# Altium schematic sheet ZBT Radio.SchDoc("ZBT Radio")
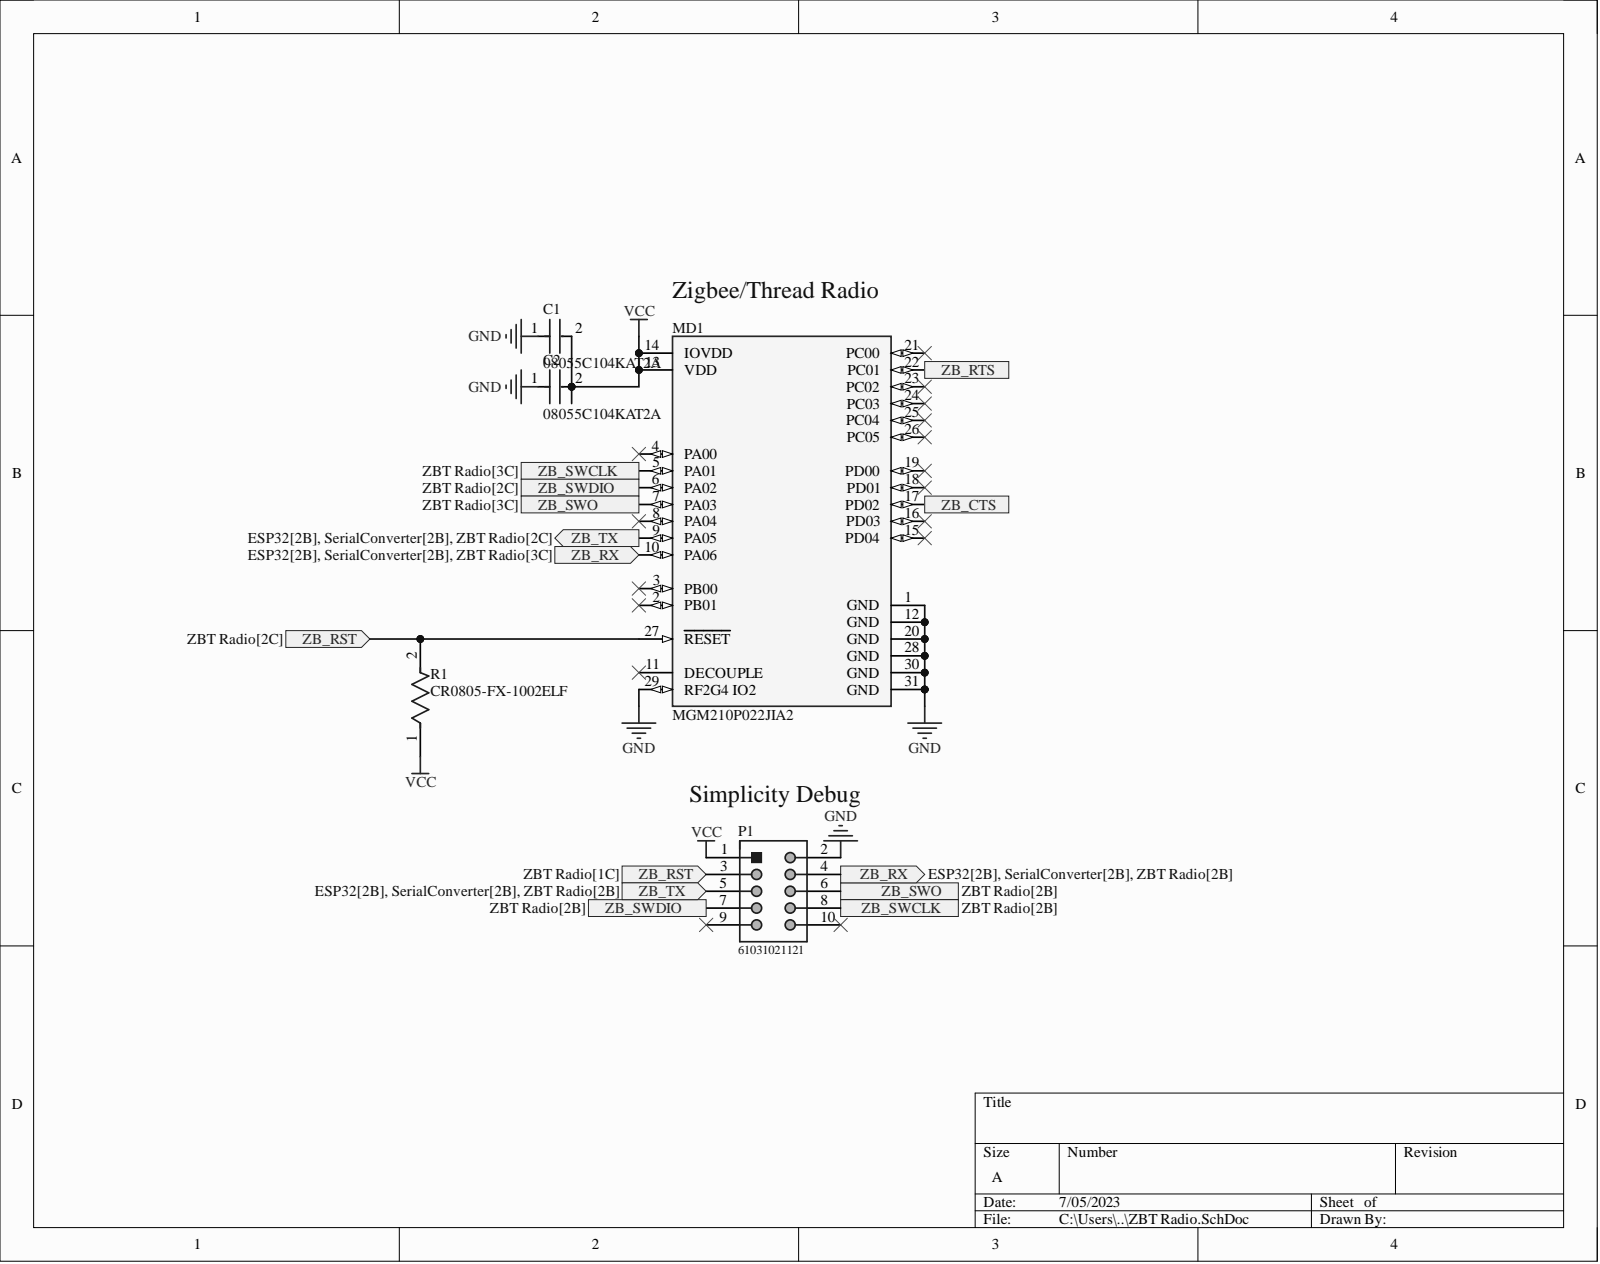
[diagram p0: ZBT Radio.SchDoc]

## Parts
C1  08055C104KAT2A  [FP-0805-L_2_01_0_2-W_1_25-IPC_C]
C2  08055C104KAT2A  [FP-0805-L_2_01_0_2-W_1_25-IPC_C]
MD1  MGM210P022JIA2  [FP-MGM210P022JIA2-MFG]
P1  61031021121  [61031021121]
R1  CR0805-FX-1002ELF  [FP-CR0805-IPC_A]

## Ports
ZB_CTS, ZB_RST, ZB_RTS, ZB_RX, ZB_SWCLK, ZB_SWDIO, ZB_SWO, ZB_TX

## Nets
GND: C1.1 C2.1 MD1.1(GND) MD1.12(GND) MD1.20(GND) MD1.28(GND) MD1.29(RF2G4_IO2) MD1.30(GND) MD1.31(GND) P1.2
VCC: C1.2 C2.2 MD1.13(VDD) MD1.14(IOVDD) P1.1 R1.1
ZB_CTS: MD1.17(PD02) ; port ZB_CTS
ZB_RST: MD1.27(RESET) P1.3 R1.2 ; port ZB_RST
ZB_RTS: MD1.22(PC01) ; port ZB_RTS
ZB_RX: MD1.10(PA06) P1.4 ; port ZB_RX
ZB_SWCLK: MD1.5(PA01) P1.8 ; port ZB_SWCLK
ZB_SWDIO: MD1.6(PA02) P1.7 ; port ZB_SWDIO
ZB_SWO: MD1.7(PA03) P1.6 ; port ZB_SWO
ZB_TX: MD1.9(PA05) P1.5 ; port ZB_TX

Not connected: MD1 2(PB01) 3(PB00) 4(PA00) 8(PA04) 11(DECOUPLE) 15(PD04) 16(PD03) 18(PD01) 19(PD00) 21(PC00) 23(PC02) 24(PC03) 25(PC04) 26(PC05); P1 9 10

## Library data of the parts
C1 C2 = CMP-2000-05016-2: General Purpose Ceramic Capacitor, 0805, 100nF, 10%, X7R, 0.15, 50V; Dissipation Factor: 2.5%; Insulation Resistance: 100GR; Capacitance: 100nF; Case Code (Imperial): 0805; Case Code (Metric): 2012; Case/Package: 0805; Component Kind: Standard; Depth: 1.25mm; Dielectric: X7R; Dielectric Material: Ceramic; Height: 0.5mm; Lead Free: Lead Free; Length: 2mm; Library Name: Altium Content Vault; Max Operating Temperature: 125°C; Min Operating Temperature: -55°C; Mount: Surface Mount; Number of Pins: 2; Package Quantity: 4000; Packaging: Cut Tape; Radiation Hardening: No; REACH SVHC: No SVHC; RoHS Compliant: Yes; Series: MLCC X7R; Termination: Standard; Thickness: 0.037inch; Tolerance: 10%; Voltage: 50V; Voltage Rating: 50V; Voltage Rating (DC): 50V; Weight: 0.001411oz; Width: 1.2mm
MD1 = CMP-08140-000019-1: RX TXRX MOD BLUETOOTH CHIP SMD; Component Kind: Standard; Library Name: Altium Content Vault
P1 = CMP-1502-01053-1: SMT Vertical Pin Header WR-PHD, Pitch 2.54 mm, Dual Row, 10 pins; Manufacturer: Wurth Elektronik; Contact Resistance: 20mOhm; Gender: Male; Pin Number: 10; Pitch: 2.54mm; Rated Current: 3A; Withstanding Voltage: 500VAC; Working Voltage: 250VAC; Component Kind: Standard; Datasheet URL: https://katalog.we-online.de/em/datasheet/6103xx21121.pdf; Library Name: Altium Content Vault; Manufacturer URL: http://www.we-online.de; Mounting Technology: SMT; Operating Temperature: -40to125degC; Orientation: Vertical; Package Reference: 61031021121; Packaging: TapeandReel; Part Number: 61031021121; Row Number: Dual; Publisher: WurthElektronik
R1 = CMP-07231-003204-1: Thick Film Chip Resistors 0805 10kΩ 0.125W 1% 100ppm/°C; Max Power Dissipation: 125mW; Resistance: 10kR; Voltage Rating: 150V; Voltage Rating (DC): 100V; Case Code (Imperial): 0805; Case Code (Metric): 2012; Case/Package: 0805; Component Kind: Standard; Composition: Thick Film; Contact Plating: Tin; Depth: 1.25mm; Features: Moisture Resistant; Height: 0.5mm; Length: 2mm; Library Name: Altium Content Vault; Max Operating Temperature: 155°C; Min Operating Temperature: -55°C; Mount: Surface Mount; Number of Terminations: 2; Package Quantity: 1; Packaging: Tape and Reel; Power Rating: 125mW; Radiation Hardening: No; REACH SVHC: No SVHC; Temperature Coefficient: 100ppm/°C; Termination: SMD/SMT; Thickness: 508um; Tolerance: 1%; Width: 1.25mm
